# Altium schematic sheet Alimentacion.SchDoc(Alimentacion)
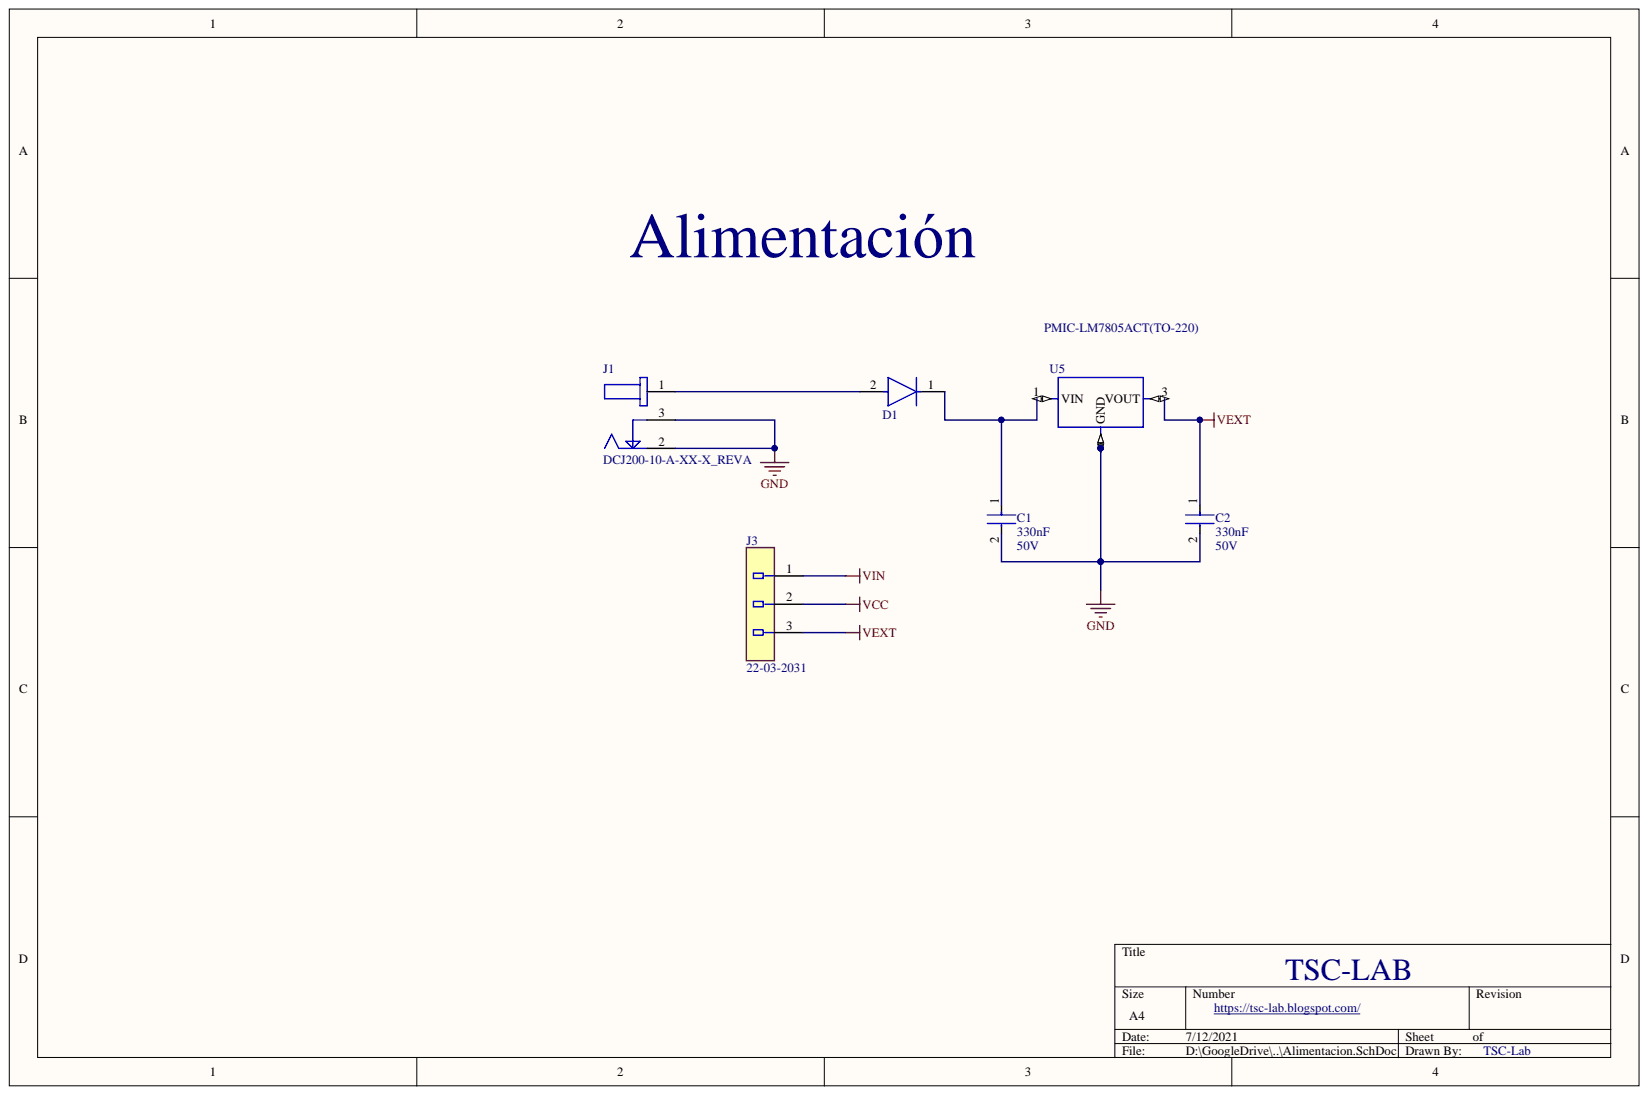
[diagram p0: Alimentacion.SchDoc]

## Parts
C1  value 330nF  Voltage: 50V  [Kemet_C322C334M5U5TA7301_0]  (hidden comment: Cap_Kemet_C322C334M5U5TA7301_eec)
C2  value 330nF  Voltage: 50V  [Kemet_C322C334M5U5TA7301_0]  (hidden comment: Cap_Kemet_C322C334M5U5TA7301_eec)
D1  Diode_Vishay_1N4001E-E3-54_eec  [Vishay_1N4001E-E3-54_0]  (value not drawn)
J1  DCJ200-10-A-XX-X_REVA  [GCT_DCJ200-10-A-XX-X_REVA]
J3  Part Number: 22-03-2031  [Molex_SD-4030-0001_0]  (hidden comment: Conn_Molex_22-03-2031_eec)
U5  PMIC-LM7805ACT(TO-220)  [TO-220]

## Nets
GND: C1.2 C2.2 J1.2 J1.3 U5.2(GND) U5.HEAT
VCC: J3.2
VEXT: C2.1 J3.3 U5.3(VOUT)
VIN: J3.1
NetC1_1: C1.1 D1.1 U5.1(VIN)
NetD1_2: D1.2 J1.1

## Library data of the parts
C1 C2 = Cap_Kemet_C322C334M5U5TA7301_eec: CAP CER 0.33UF 50V Z5U RADIAL; Manufacturer: Kemet; Temperature Range Low: -10°C; Temperature Range High: +85°C; Temperature: -10°C to +85°C; Supplier Part Number 2: 80-C322C334M5U-TR; Supplier Part Number 1: 399-9882-1-ND; Supplier 2: Mouser; Supplier 1: Digi-Key; RoHS: TRUE; Price: 0.206679296795; Part Number: C322C334M5U5TA7301; Package: AXIAL; Mouser Part Number: 80-C322C334M5U-TR; Library Name: SnapEDA_Library.IntLib; Lead Free: yes; helpURL: https://upverter.com/upn/revision/a7e23f606e787d17/; Digikey Part Number: 399-9882-1-ND; Digikey Description: CAP CER 0.33UF 50V Z5U RADIAL; ComponentLink2URL: http://www.mouser.com/ds/2/212/KEM_C1051_GOLDMAX_Z5U-1140498.pdf; ComponentLink2Description: Datasheet; ComponentLink1URL: https://upverter.com/upn/revision/a7e23f606e787d17/; ComponentLink1Description: Upverter; Component Kind: Standard; Capacitance: 330nF
D1 = Diode_Vishay_1N4001E-E3-54_eec: DIODE GEN PURP 50V 1A DO204AL; Part Number: 1N4001E-E3/54; Manufacturer: Vishay; Voltage - Forward (Vf) (Max) @ If: 1.1V @ 1A; Speed: Standard Recovery >500ns, > 200mA (Io); Other Names: IN4001E-E3/54; Diode Type: Standard; Current - Reverse Leakage @ Vr: 5µA @ 50V; Capacitance @ Vr, F: 15pF @ 4V, 1MHz; Voltage - DC Reverse (Vr) (Max): 50V; Voltage: 50V; Temperature Range Low: -50°C; Temperature Range High: +150°C; Temperature: -50°C to +150°C; Supplier Part Number 2: 625-1N4001E-E3/54; Supplier Part Number 1: 1N4001E-E3/54GICT-ND; Supplier 2: Mouser; Supplier 1: Digi-Key; Standard Package: 5,500; RoHS: TRUE; Packaging: Tape & Reel (TR); Package: DO41; Mouser Part Number: 625-1N4001E-E3/54; Mounting Type: Through Hole; Library Name: SnapEDA_Library.IntLib; Lead Free: yes; Ipc Land Pattern Name: DIOAD852W85L465D235; helpURL: https://upverter.com/upn/revision/7d4f72d520b50cd6/; Digikey Part Number: 1N4001E-E3/54GICT-ND; Digikey Description: DIODE GEN PURP 50V 1A DO204AL; Current - Average Rectified (Io): 1A; ComponentLink2URL: http://www.vishay.com/doc?88503; ComponentLink2Description: Datasheet; ComponentLink1URL: https://upverter.com/upn/revision/7d4f72d520b50cd6/; ComponentLink1Description: Upverter; Component Kind: Standard
J1 = DCJ200-10-A-XX-X_REVA: DC Power Jack, Thru-Hole, Horizontal, with Locating Pegs, D2.0mm; MF: {'manufacturer': u'Global Connector Technology'}; Price: None; Package: None; MP: DCJ200-10-A-XX-X_REVA; Library Name: SnapEDA_Library.IntLib; Component Kind: Standard; Availability: Unavailable
J3 = Conn_Molex_22-03-2031_eec: CONN HEADER 3POS .100 VERT TIN; Manufacturer: Molex; Voltage: 150V; Num Pins: 3; Mfg Package Ident: SD-4030-0001; Current___Maximum_per_Contact: 4.0A; Type: connector; Temperature Range Low: -40°C; Temperature Range High: +80°C; Temperature: -40°C to +80°C; Supplier Part Number 2: 538-22-03-2031; Supplier Part Number 1: WM4001-ND; Supplier 2: Mouser; Supplier 1: Digi-Key; RoHS: TRUE; Price: 0.17996592642; Package: SD-4030-0001; Mouser Part Number: 538-22-03-2031; Library Name: SnapEDA_Library.IntLib; Lead Free: yes; helpURL: https://upverter.com/upn/revision/895d404c69e3c851/; Flammability: 94V-0; Digikey Part Number: WM4001-ND; Digikey Description: CONN HEADER 3POS .100 VERT TIN; ComponentLink2URL: http://www.molex.com/pdm_docs/sd/022032031_sd.pdf; ComponentLink2Description: Datasheet; ComponentLink1URL: https://upverter.com/upn/revision/895d404c69e3c851/; ComponentLink1Description: Upverter; Component Kind: Standard; Circuits_Loaded: 3
U5 = PMIC-LM7805ACT(TO-220): 310030049; MF: {'manufacturer': u'ON Semiconductor'}; Price: None; Package: None; MP: LM7805ACT; Library Name: SnapEDA_Library.IntLib; Component Kind: Standard; Availability: Unavailable
